# Altium schematic sheet Top.SchDoc(Top)
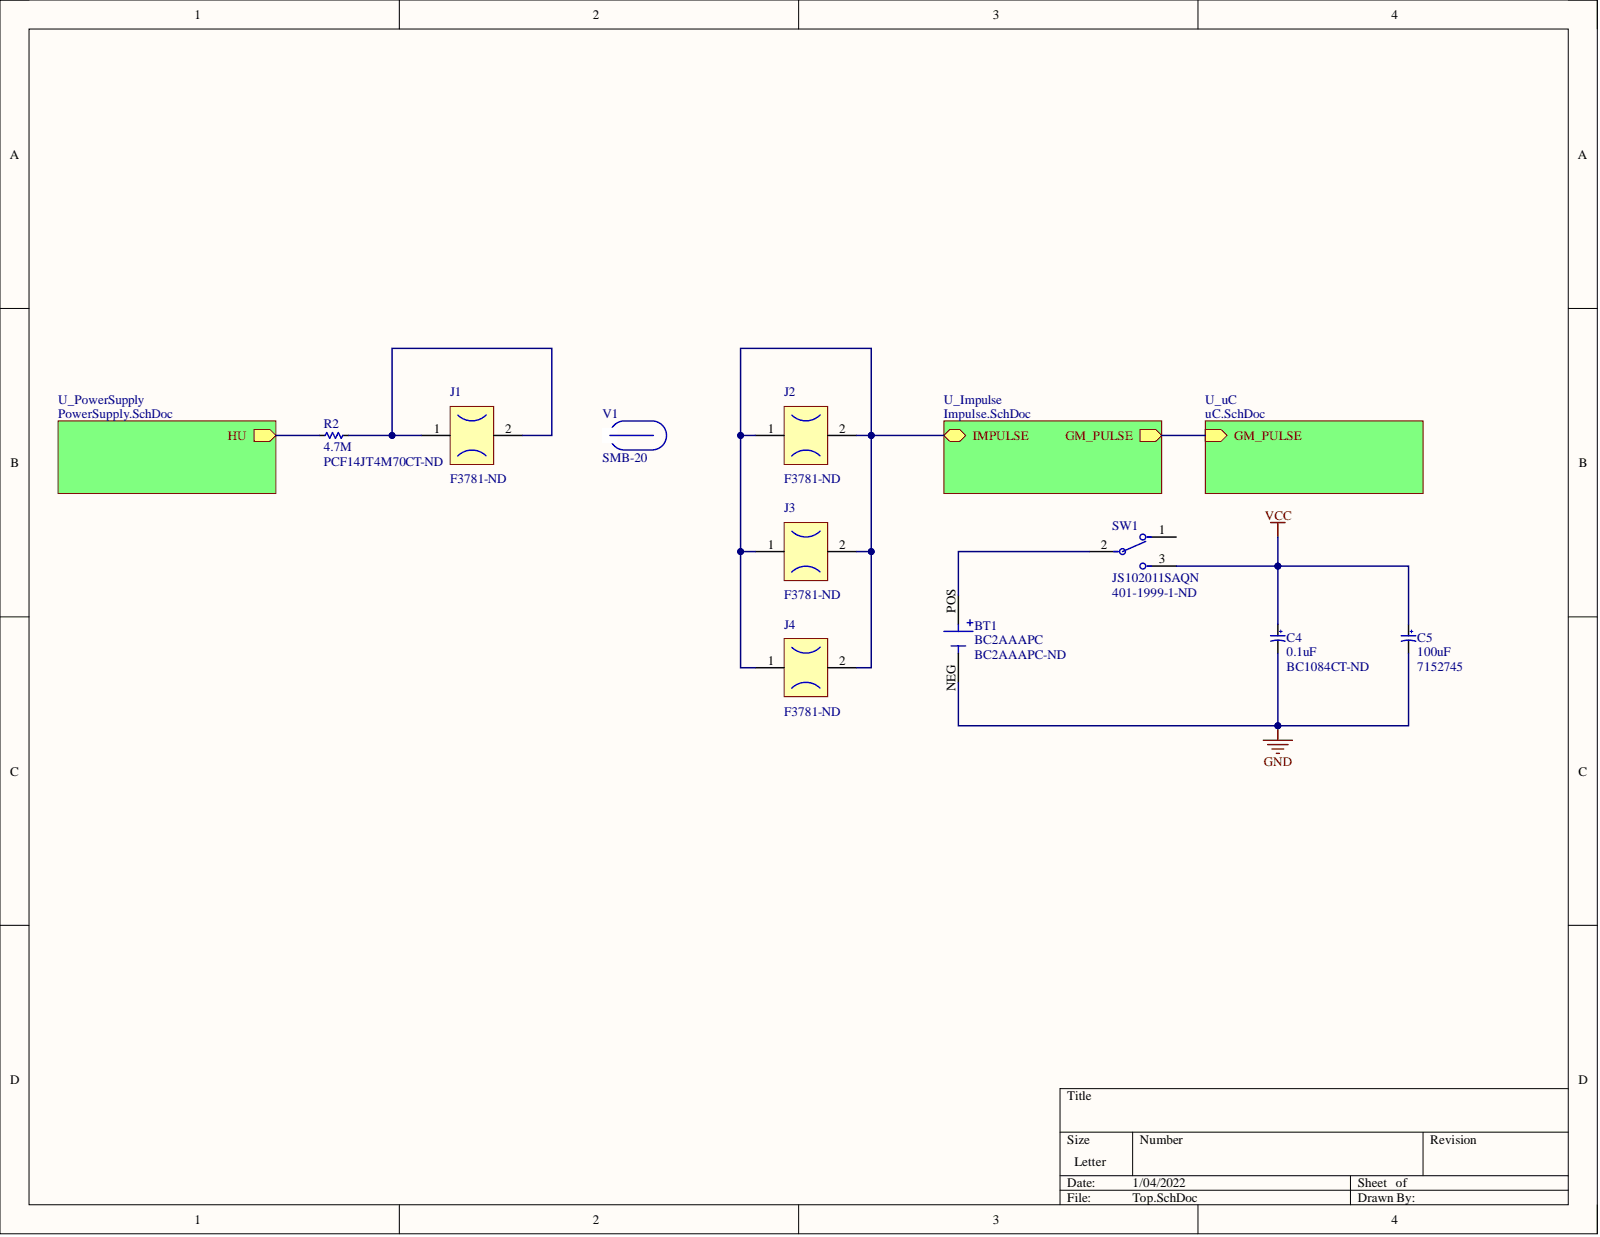
[diagram p0: Top.SchDoc]

## Parts
BT1  BC2AAAPC  Supplier Part Number 1: BC2AAAPC-ND
C4  0.1uF  Supplier Part Number 1: BC1084CT-ND
C5  100uF  Supplier Part Number 1: 7152745
J1  Supplier Part Number 1: F3781-ND  (hidden comment: Fuse Holder)
J2  Supplier Part Number 1: F3781-ND  (hidden comment: Fuse Holder)
J3  Supplier Part Number 1: F3781-ND  (hidden comment: Fuse Holder)
J4  Supplier Part Number 1: F3781-ND  (hidden comment: Fuse Holder)
R2  4.7M
SW1  JS102011SAQN  Supplier Part Number 1: 401-1999-1-ND
V1  SMB-20

## Nets
GND: BT1.NEG C4.2 C5.2
VCC: C4.1 C5.1 SW1.3
NetBT1_POS: BT1.POS SW1.2
NetJ1_1: J1.1 J1.2 R2.2
NetJ2_1: J2.1 J2.2 J3.1 J3.2 J4.1 J4.2
NetR2_1: R2.1
NetSW1_1: SW1.1
GM_PULSE:

## Library data of the parts
BT1 = BC2AAAPC: BATTERY HOLDER AAA 2 CELL PC PIN; MF: MPD; Availability: Unavailable; Component Kind: Standard; MP: BC2AAAPC; Package: None; Price: None; SnapEDA_Link: https://www.snapeda.com/parts/BC2AAAPC/MPD/view-part/4389080/?ref=snap; Supplier 1: Digi-Key; Library Name: GeigerLibrary_CHU.SCHLIB
C4 = K104K15X7RF5TL2: Multilayer Ceramic Capacitors (MLCC) - Leaded 0.1uF 50volts 10% X7R 2.5mm LS; Manufacturer: Vishay; Component Kind: Standard; Manufacturer PN: K104K15X7RF5TL2; Supplier 1: Digi-Key; Value: 0.1uF; Library Name: GeigerLibrary_CHU.SCHLIB
C5 = UPW1V101MPD: Aluminum Electrolytic Capacitors - Leaded 35volts 100uF; Manufacturer: Nichicon; Component Kind: Standard; Manufacturer PN: UPW1V101MPD; Supplier 1: RSComponents; Value: 100uF; Library Name: GeigerLibrary_CHU.SCHLIB
J1-J4 = Fuse Holder: Manufacturer: LIttlefuse; Manufacturer PN: 01020071Z; Component Kind: Standard; Description: Fuse Holder; Supplier 1: Digi-Key; Library Name: GeigerLibrary_CHU.SCHLIB
R2 = PCF14JT4M70: RES 4.7M OHM 1/4W 5% AXIAL; Manufacturer: Stackpole; Power: 1/4 Watt; Tolerance: 0.05; Component Kind: Standard; Manufacturer PN: PCF14JT4M70; Package Type: Axial; Supplier 1: Digi-Key; Supplier Part Number 1: PCF14JT4M70CT-ND; Value: 4.7M; Library Name: GeigerLibrary_CHU.SCHLIB
SW1 = CMP-2000-06501-2: SWITCH SLIDE SPDT 300MA 6V; Manufacturer: ITT C&K; Actuator Length: 2mm; Actuator Material: Nylon; Actuator Type: Standard; Contact Resistance: 70mR; Electrical Life: 5000Cycles; Illumination Type: Non-Illuminated; Switch Function: On-On; Case/Package: 100; Component Kind: Standard; Contact Current Rating: 300mA; Contact Material: Copper Alloy; Contact Plating: Silver; Current Rating: 300mA; Housing Material: Nylon; Lead Free: Lead Free; Length: 9mm; Manufacturer Part Number: JS102011SAQN; Max Current Rating: 300mA; Max Operating Temperature: 85°C; Max Voltage Rating (DC): 6V; Min Operating Temperature: -40°C; Mount: Surface Mount; Orientation: Right Angle; Package Quantity: 1; Packaging: Tape and Reel; Radiation Hardening: No; REACH SVHC: No SVHC; Supplier 1: Digi-Key; Switch Travel: 2mm; Termination: Gull Wing; Throw Configuration: SPDT; Voltage Rating (DC): 6V; Library Name: GeigerLibrary_CHU.SCHLIB
V1 = SBM-20-CHU: SMB-20 Geiger-Muller Tube; Manufacturer: Where Sold; Component Kind: Standard; Library Name: GeigerLibrary_CHU.IntLib; Manufacturer PN: SMB-20
